# Altium schematic sheet E_Album.SchDoc(E_Album)
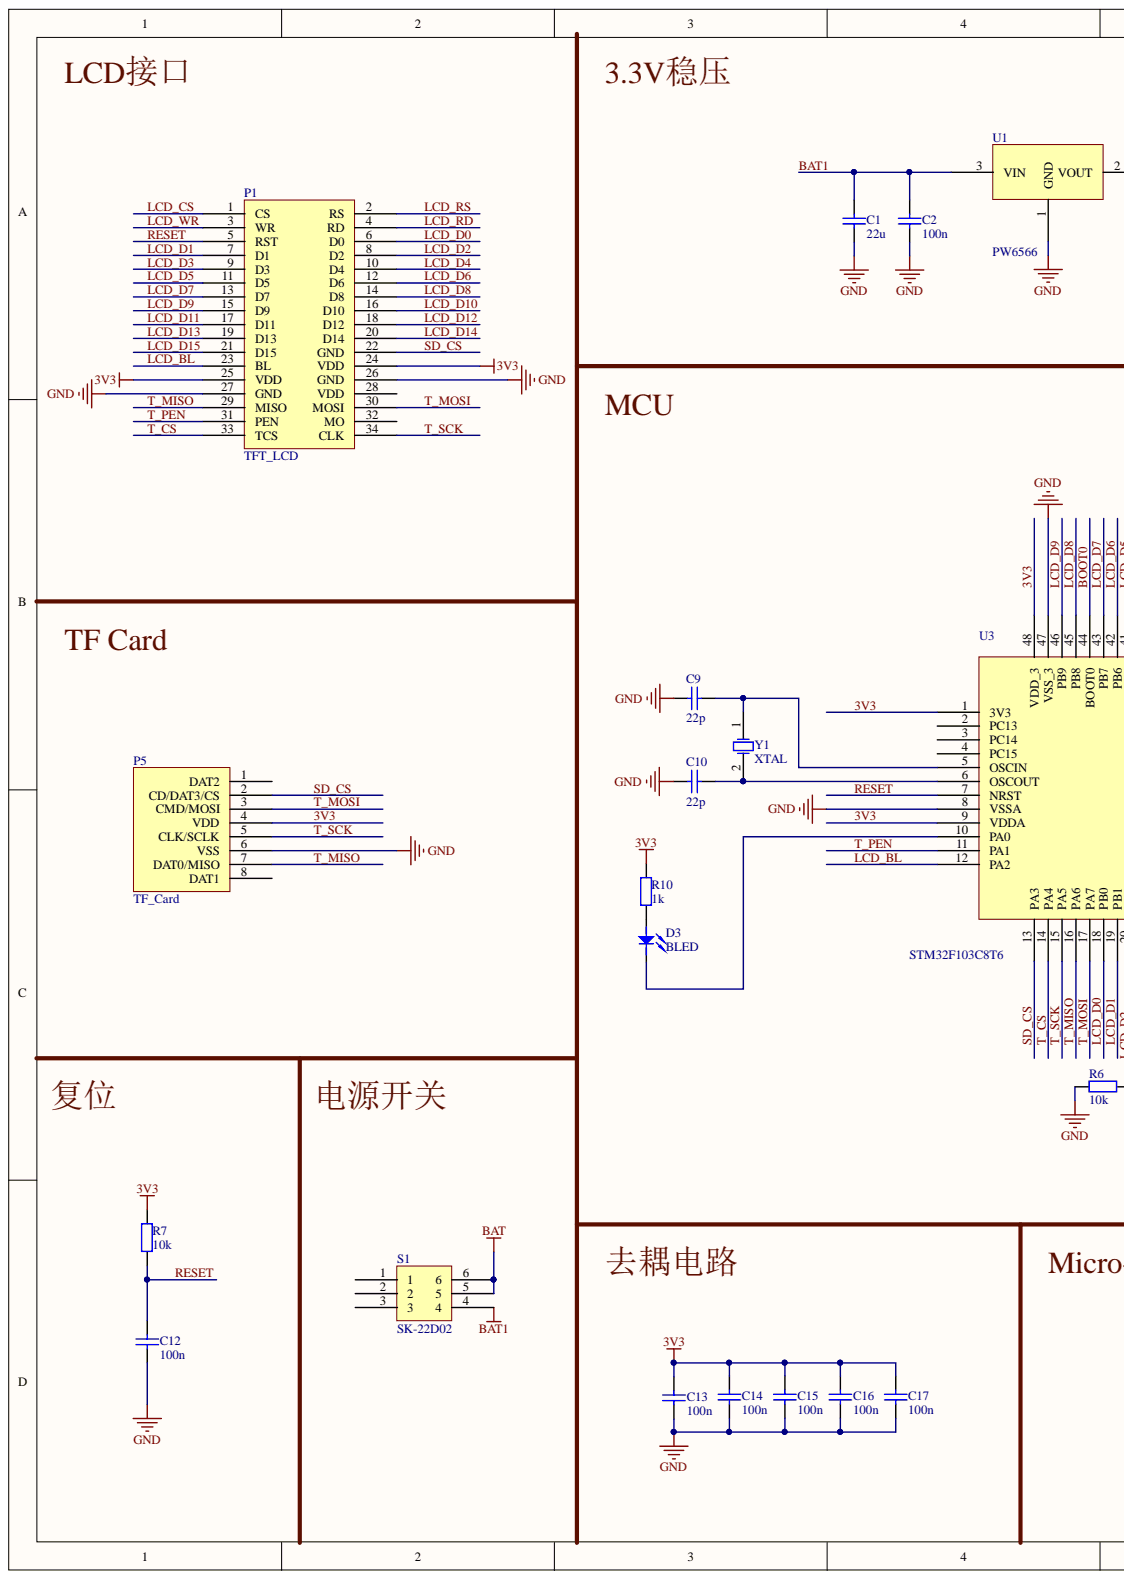
[diagram p0: E_Album.SchDoc, left]
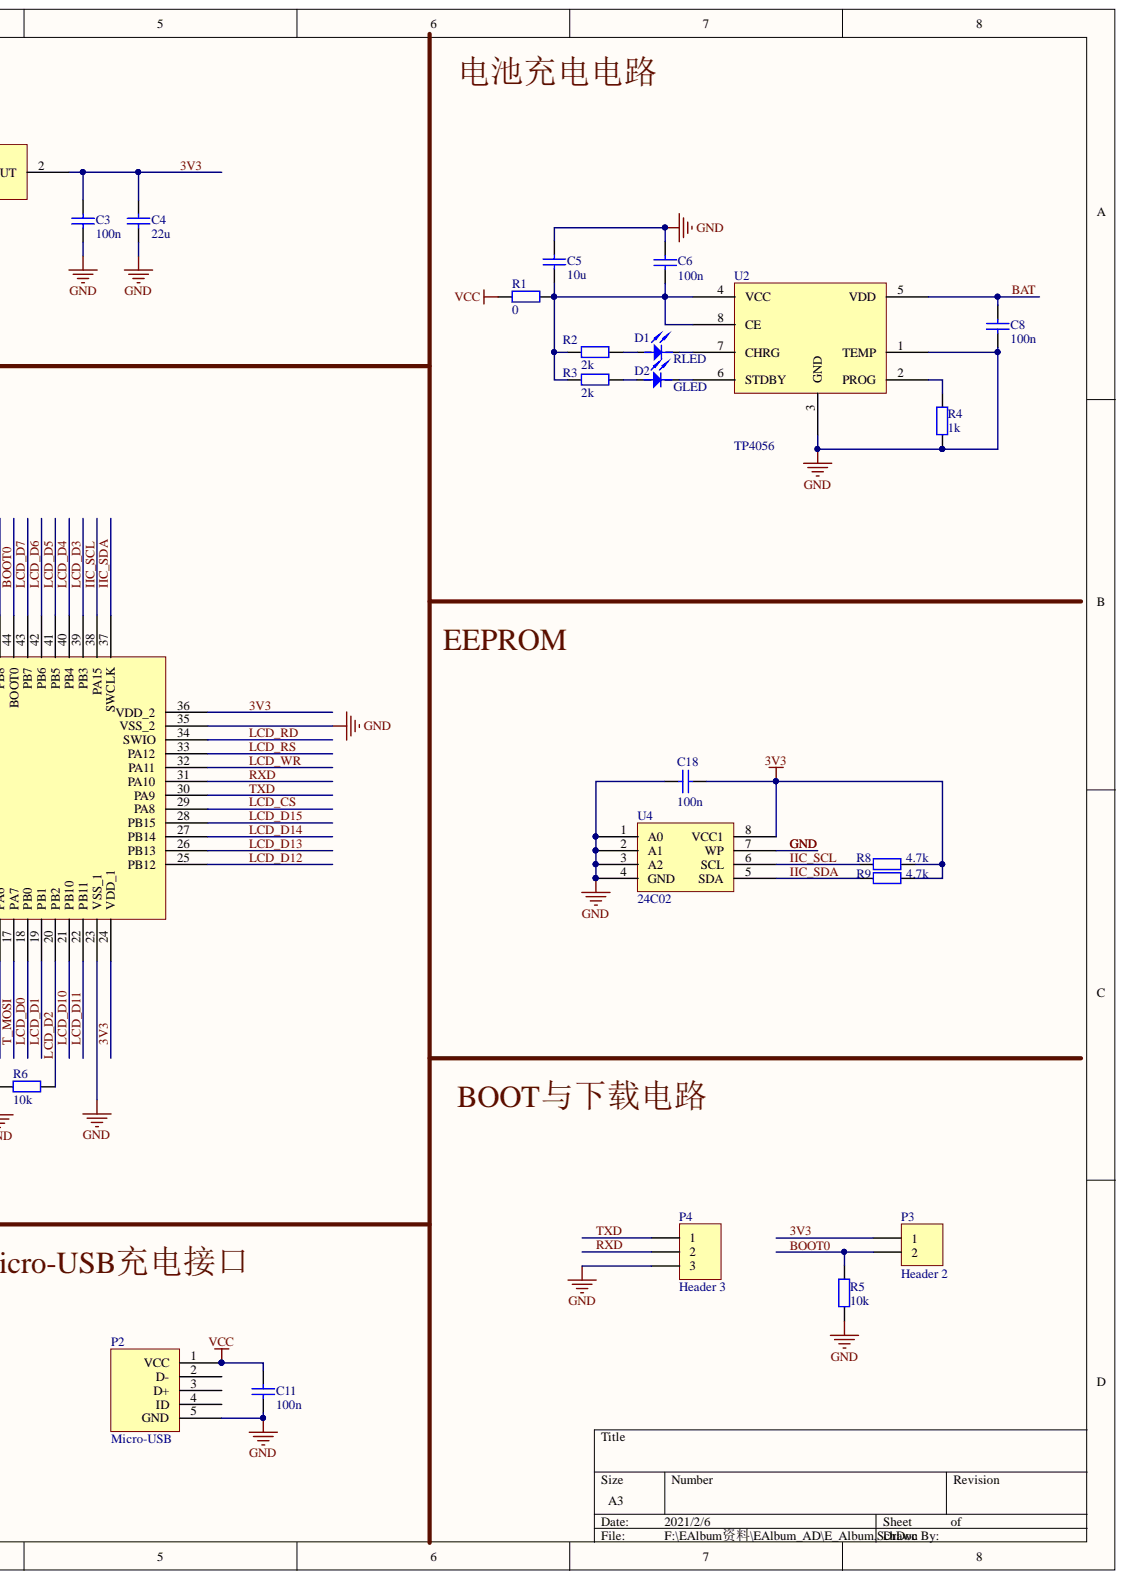
[diagram p1: E_Album.SchDoc, right]

## Parts
C1  22u  [CAP(SMD 0805)]
C2  100n  [C-0603]
C3  100n  [C-0603]
C4  22u  [CAP(SMD 0805)]
C5  10u  [CAP(SMD 0805)]
C6  100n  [C-0603]
C8  100n  [C-0603]
C9  22p  [C-0603]
C10  22p  [C-0603]
C11  100n  [C-0603]
C12  100n  [C-0603]
C13  100n  [C-0603]
C14  100n  [C-0603]
C15  100n  [C-0603]
C16  100n  [C-0603]
C17  100n  [C-0603]
C18  100n  [C-0603]
D1  RLED  [LED 0603R]
D2  GLED  [LED 0603G]
D3  BLED  [LED 0603B]
P1  TFT_LCD  [HDR2.54-LI-2x17P]
P2  Micro-USB  [MICRO-USB-牛角插板-无卷边]
P3  Header 2  [HDR2.54-WI-2P]
P4  Header 3  [HDR2.54-WI-3P]
P5  TF_Card  [TF卡座 自弹]
R1  0  [R-0603-M]
R2  2k  [R-0603-M]
R3  2k  [R-0603-M]
R4  1k  [R-0603-M]
R5  10k  [R-0603-M]
R6  10k  [R-0603-M]
R7  10k  [R-0603-M]
R8  4.7k  [R-0603-M]
R9  4.7k  [R-0603-M]
R10  1k  [R-0603-M]
S1  SK-22D02  [SK-22D02]
U1  PW6566  [SOT-23]
U2  TP4056  [SOP-8-M]
U3  STM32F103C8T6  [LQFP48-N]
U4  24C02  [SOP-8-M]
Y1  XTAL  [OSC HC-49S]

## Nets
VCC: C11.2 P2.1(VCC) R1.1
3V3: C3.2 C4.2 C13.2 C14.2 C15.2 C16.2 C17.2 C18.2 P1.24(VDD) P1.25(VDD) P3.1 P5.4(VDD) R7.2 R8.2 R9.2 R10.2 U1.2 U3.1(3V3) U3.9(VDDA) U3.24(VDD_1) U3.36(VDD_2) U3.48(VDD_3) U4.8(VCC1)
BAT: C8.2 S1.5 S1.6 U2.5(VDD)
BAT1: C1.2 C2.2 S1.4 U1.3
BOOT0: P3.2 R5.2 U3.44(BOOT0)
GND: C1.1 C2.1 C3.1 C4.1 C5.2 C6.2 C8.1 C10.1 C11.1 C12.1 C13.1 C14.1 C15.1 C16.1 C17.1 C18.1 P1.26(GND) P1.27(GND) P2.5(GND) P4.3 P5.6(VSS) R4.1 R5.1 R6.2 U1.1 U2.1(TEMP) U2.3(GND) U3.8(VSSA) U3.23(VSS_1) U3.35(VSS_2) U3.47(VSS_3) U4.1(A0) U4.2(A1) U4.3(A2) U4.4(GND) U4.7(WP)
IIC_SCL: R8.1 U3.38(PA15) U4.6(SCL)
IIC_SDA: R9.1 U3.37(SWCLK) U4.5(SDA)
LCD_BL: P1.23(BL) U3.12(PA2)
LCD_CS: P1.1(CS) U3.29(PA8)
LCD_D0: P1.6(D0) U3.18(PB0)
LCD_D1: P1.7(D1) U3.19(PB1)
LCD_D2: P1.8(D2) R6.1 U3.20(PB2)
LCD_D3: P1.9(D3) U3.39(PB3)
LCD_D4: P1.10(D4) U3.40(PB4)
LCD_D5: P1.11(D5) U3.41(PB5)
LCD_D6: P1.12(D6) U3.42(PB6)
LCD_D7: P1.13(D7) U3.43(PB7)
LCD_D8: P1.14(D8) U3.45(PB8)
LCD_D9: P1.15(D9) U3.46(PB9)
LCD_D10: P1.16(D10) U3.21(PB10)
LCD_D11: P1.17(D11) U3.22(PB11)
LCD_D12: P1.18(D12) U3.25(PB12)
LCD_D13: P1.19(D13) U3.26(PB13)
LCD_D14: P1.20(D14) U3.27(PB14)
LCD_D15: P1.21(D15) U3.28(PB15)
LCD_RD: P1.4(RD) U3.34(SWIO)
LCD_RS: P1.2(RS) U3.33(PA12)
LCD_WR: P1.3(WR) U3.32(PA11)
RESET: C12.2 P1.5(RST) R7.1 U3.7(NRST)
RXD: P4.2 U3.31(PA10)
SD_CS: P1.22(GND) P5.2(CD/DAT3/CS) U3.13(PA3)
T_CS: P1.33(TCS) U3.14(PA4)
T_MISO: P1.29(MISO) P5.7(DAT0/MISO) U3.16(PA6)
T_MOSI: P1.30(MOSI) P5.3(CMD/MOSI) U3.17(PA7)
T_PEN: P1.31(PEN) U3.11(PA1)
T_SCK: P1.34(CLK) P5.5(CLK/SCLK) U3.15(PA5)
TXD: P4.1 U3.30(PA9)
NetC5_1: C5.1 C6.1 R1.2 R2.1 R3.1 U2.4(VCC) U2.8(CE)
NetC9_2: C9.2 U3.5(OSCIN) Y1.1
NetC10_2: C10.2 U3.6(OSCOUT) Y1.2
NetD1_1: D1.1 R2.2
NetD1_2: D1.2 U2.7(CHRG)
NetD2_1: D2.1 R3.2
NetD2_2: D2.2 U2.6(STDBY)
NetD3_1: D3.1 R10.1
NetD3_2: D3.2 U3.10(PA0)
NetR4_2: R4.2 U2.2(PROG)

Not connected: C9 1; P1 28(VDD) 32(MO); P2 2(D-) 3(D+) 4(ID); P5 1(DAT2) 8(DAT1); S1 1 2 3; U3 2(PC13) 3(PC14) 4(PC15)

## Library data of the parts
C1-C6 C8-C18 = Cap: Library Name: General Components.SchLib; Component Kind: Standard
D1-D3 = LED: Library Name: General Components.SchLib; Component Kind: Standard
P1 = TFT_LCD_port: Component Kind: Standard; Library Name: Powerlib.SchLib
P2 = Type_C: Component Kind: Standard; Library Name: Powerlib.SchLib
P3 = Header 2: Header, 2-Pin; Signal Integrity: Connector; Library Name: Miscellaneous Connectors.IntLib; LatestRevisionNote: Re-released for DXP Platform.; LatestRevisionDate: 17-Jul-2002; Component Kind: Standard; Publisher: Altium Limited
P4 = Header 3: Header, 3-Pin; Signal Integrity: Connector; Library Name: Miscellaneous Connectors.IntLib; LatestRevisionNote: Re-released for DXP Platform.; LatestRevisionDate: 17-Jul-2002; Component Kind: Standard; Publisher: Altium Limited
R1-R10 = Res: Library Name: General Components.SchLib; Component Kind: Standard
Y1 = XTAL: Crystal Oscillator; Published: 8-Jun-2000; PackageReference: R38; PackageDescription: Crystal, Thru-Hole; 2 Leads; Body 3.1 x 8.2 mm (Dia.xH); Library Name: General Components.SchLib; LatestRevisionNote: Re-released for DXP Platform.; LatestRevisionDate: 17-Jul-2002; Component Kind: Standard; Publisher: Altium Limited
P5 = TF_Card: Component Kind: Standard; Library Name: Powerlib.SchLib
S1 = SK-22D02: Component Kind: Standard; Library Name: Powerlib.SchLib
U1 = PW6566: Component Kind: Standard; Library Name: Powerlib.SchLib
U2 = TP4056: Component Kind: Standard; Library Name: Powerlib.SchLib
U3 = STM32F103C8T6: Component Kind: Standard; Library Name: Powerlib.SchLib
U4 = 24C02: Component Kind: Standard; Library Name: Powerlib.SchLib
